# Altium schematic sheet Brainy Modul.SchDoc("Brainy Modul")
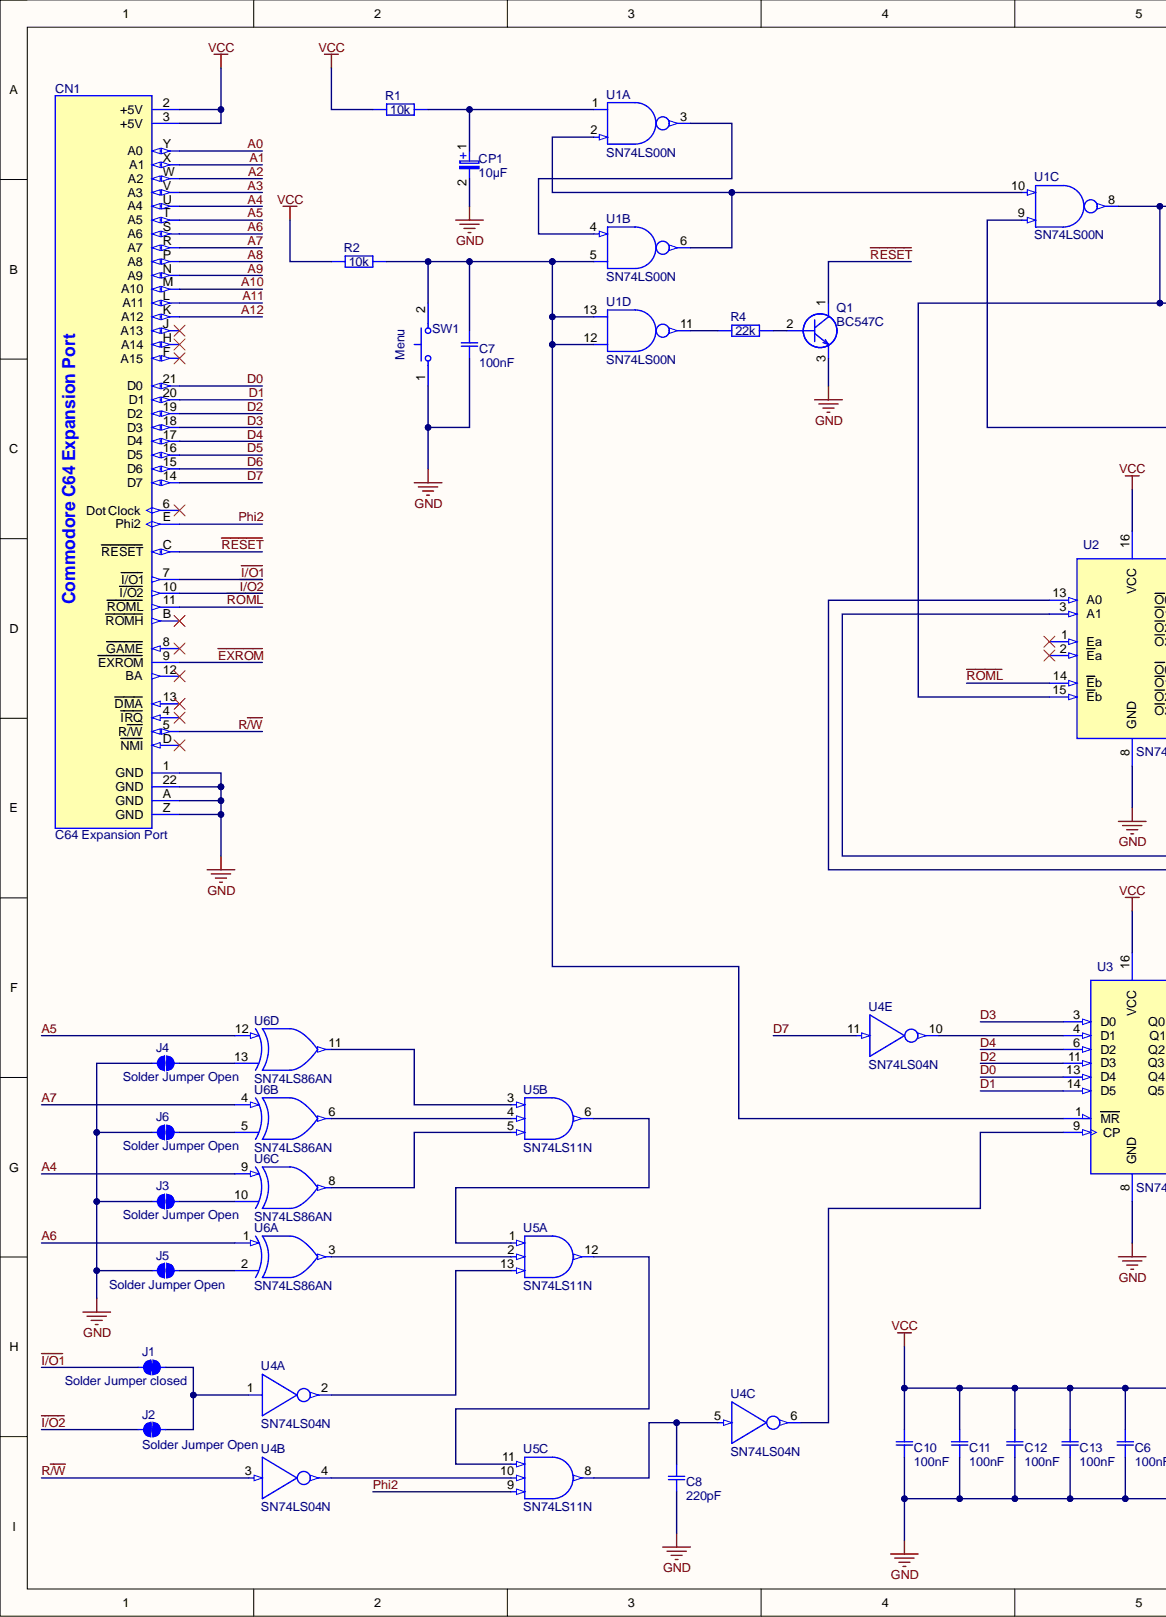
[diagram p0: Brainy Modul.SchDoc, left]
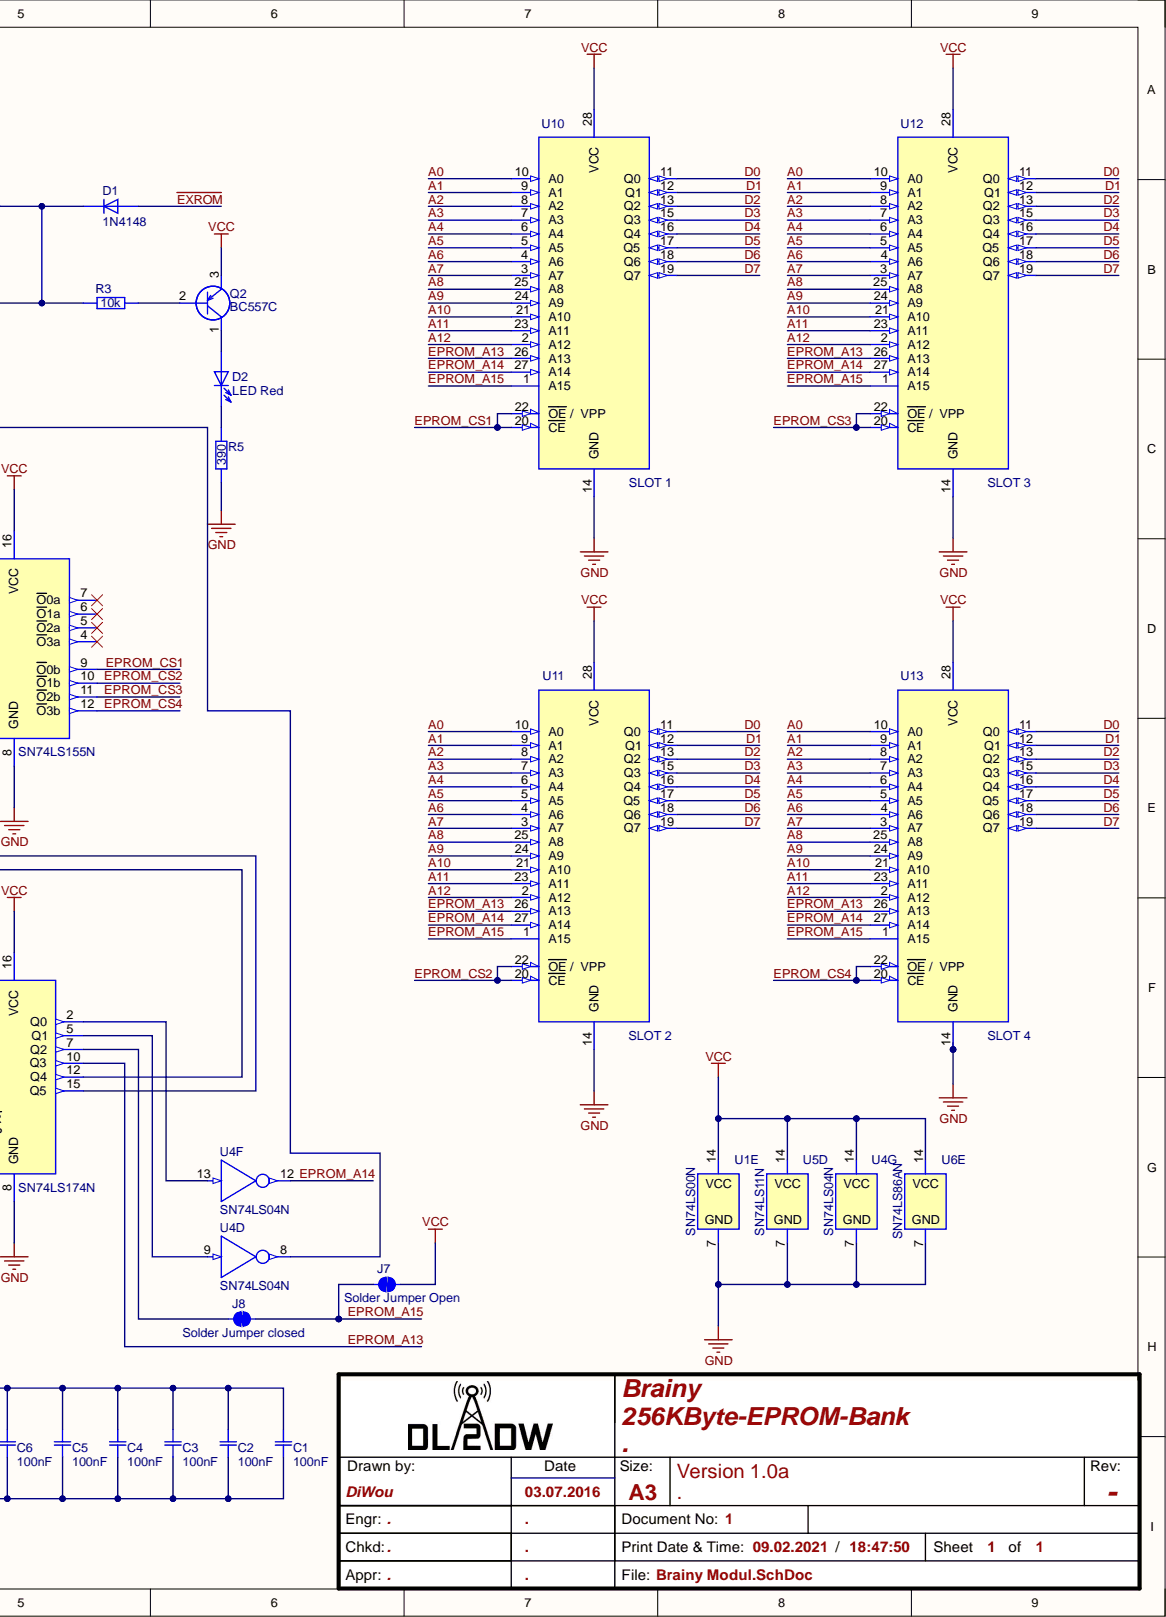
[diagram p1: Brainy Modul.SchDoc, right]

## Parts
C1  100nF  [CAP Disc 100nF P2.54mm D5.5mm T3mm]
C2  100nF  [CAP Disc 100nF P2.54mm D5.5mm T3mm]
C3  100nF  [CAP Disc 100nF P2.54mm D5.5mm T3mm]
C4  100nF  [CAP Disc 100nF P2.54mm D5.5mm T3mm]
C5  100nF  [CAP Disc 100nF P2.54mm D5.5mm T3mm]
C6  100nF  [CAP Disc 100nF P2.54mm D5.5mm T3mm]
C7  100nF  [CAP Disc 100nF P2.54mm D5.5mm T3mm]
C8  220pF  [KERKO 220P 63V RM2.5mm]
C10  100nF  [CAP Disc 100nF P2.54mm D5.5mm T3mm]
C11  100nF  [CAP Disc 100nF P2.54mm D5.5mm T3mm]
C12  100nF  [CAP Disc 100nF P2.54mm D5.5mm T3mm]
C13  100nF  [CAP Disc 100nF P2.54mm D5.5mm T3mm]
CN1  C64 Expansion Port  [C64 Expansion Port EDGE Connector]  (no BOM)
CP1  10µF  [CAP TAN 10µF T378E106K025AS P5.08mm]
D1  1N4148  [1N4148 P=5.08mm]
D2  LED Red  Color: Red  [LED 3mm Red Straight]
J1  Solder Jumper closed  [Solder Jumper Closed]
J2  Solder Jumper Open  [Solder Jumper Open Variant 2]  (no BOM)
J3  Solder Jumper Open  [Solder Jumper Open Variant 2]  (no BOM)
J4  Solder Jumper Open  [Solder Jumper Open Variant 2]  (no BOM)
J5  Solder Jumper Open  [Solder Jumper Open Variant 2]  (no BOM)
J6  Solder Jumper Open  [Solder Jumper Open Variant 2]  (no BOM)
J7  Solder Jumper Open  [Solder Jumper Open Variant 2]  (no BOM)
J8  Solder Jumper closed  [Solder Jumper Closed]
Q1  BC547C  [BC547C]
Q2  BC557C  [BC557C]
R1  10k  [10 kOhm R Axial DIN0207 L6.3mm D2.5mm P7.62mm Horizontal]
R2  10k  [10 kOhm R Axial DIN0207 L6.3mm D2.5mm P7.62mm Horizontal]
R3  10k  [10 kOhm R Axial DIN0207 L6.3mm D2.5mm P7.62mm Horizontal]
R4  22k  [22 kOhm R Axial DIN0207 L6.3mm D2.5mm P7.62mm Horizontal]
R5  390  [390 Ohm R Axial DIN0207 L6.3mm D2.5mm P7.62mm Horizontal]
SW1  Menu  [Tactile Switch Push Button Right Angle L=8.35mm]
U1  SN74LS00N  [SN74LS00N with Socket]  (parts A,B,C,D,E)
U2  SN74LS155N  [SN74LS155N with Socket]
U3  SN74LS174N  [SN74LS174N with Socket]
U4  SN74LS04N  [SN74LS04N with Socket]  (parts A,B,C,D,E,F,G)
U5  SN74LS11N  [SN74LS11N with Socket]  (parts A,B,C,D)
U6  SN74LS86AN  [SN74LS86N with Socket]  (parts A,B,C,D,E)
U10  SLOT 1  [Winbond W27C512-45Z DIP-28 with Socket]
U11  SLOT 2  [Winbond W27C512-45Z DIP-28 with Socket]
U12  SLOT 3  [Winbond W27C512-45Z DIP-28 with Socket]
U13  SLOT 4  [Winbond W27C512-45Z DIP-28 with Socket]

## Nets
GND: C1.1 C2.1 C3.1 C4.1 C5.1 C6.1 C7.1 C8.1 C10.1 C11.1 C12.1 C13.1 CN1.1(GND) CN1.22(GND) CN1.A(GND) CN1.Z(GND) CP1.2 J3.1 J4.1 J5.1 J6.1 Q1.3 R5.1 SW1.1 U1.7 U2.8(GND) U3.8(GND) U4.7 U5.7 U6.7 U10.14(GND) U11.14(GND) U12.14(GND) U13.14(GND)
VCC: C1.2 C2.2 C3.2 C4.2 C5.2 C6.2 C10.2 C11.2 C12.2 C13.2 CN1.2(+5V) CN1.3(+5V) J7.2 Q2.3 R1.1 R2.1 U1.14 U2.16(VCC) U3.16(VCC) U4.14 U5.14 U6.14 U10.28(VCC) U11.28(VCC) U12.28(VCC) U13.28(VCC)
~{EXROM}: CN1.9(EXROM) D1.2
~{I/O1}: CN1.7(I/O1) J1.1
~{I/O2}: CN1.10(I/O2) J2.1
~{RESET}: CN1.C(RESET) Q1.1
~{ROML}: CN1.11(ROML) U2.14(Eb)
A0: CN1.Y(A0) U10.10(A0) U11.10(A0) U12.10(A0) U13.10(A0)
A1: CN1.X(A1) U10.9(A1) U11.9(A1) U12.9(A1) U13.9(A1)
A2: CN1.W(A2) U10.8(A2) U11.8(A2) U12.8(A2) U13.8(A2)
A3: CN1.V(A3) U10.7(A3) U11.7(A3) U12.7(A3) U13.7(A3)
A4: CN1.U(A4) U6.9 U10.6(A4) U11.6(A4) U12.6(A4) U13.6(A4)
A5: CN1.T(A5) U6.12 U10.5(A5) U11.5(A5) U12.5(A5) U13.5(A5)
A6: CN1.S(A6) U6.1 U10.4(A6) U11.4(A6) U12.4(A6) U13.4(A6)
A7: CN1.R(A7) U6.4 U10.3(A7) U11.3(A7) U12.3(A7) U13.3(A7)
A8: CN1.P(A8) U10.25(A8) U11.25(A8) U12.25(A8) U13.25(A8)
A9: CN1.N(A9) U10.24(A9) U11.24(A9) U12.24(A9) U13.24(A9)
A10: CN1.M(A10) U10.21(A10) U11.21(A10) U12.21(A10) U13.21(A10)
A11: CN1.L(A11) U10.23(A11) U11.23(A11) U12.23(A11) U13.23(A11)
A12: CN1.K(A12) U10.2(A12) U11.2(A12) U12.2(A12) U13.2(A12)
D0: CN1.21(D0) U3.13(D4) U10.11(Q0) U11.11(Q0) U12.11(Q0) U13.11(Q0)
D1: CN1.20(D1) U3.14(D5) U10.12(Q1) U11.12(Q1) U12.12(Q1) U13.12(Q1)
D2: CN1.19(D2) U3.11(D3) U10.13(Q2) U11.13(Q2) U12.13(Q2) U13.13(Q2)
D3: CN1.18(D3) U3.3(D0) U10.15(Q3) U11.15(Q3) U12.15(Q3) U13.15(Q3)
D4: CN1.17(D4) U3.6(D2) U10.16(Q4) U11.16(Q4) U12.16(Q4) U13.16(Q4)
D5: CN1.16(D5) U10.17(Q5) U11.17(Q5) U12.17(Q5) U13.17(Q5)
D6: CN1.15(D6) U10.18(Q6) U11.18(Q6) U12.18(Q6) U13.18(Q6)
D7: CN1.14(D7) U4.11 U10.19(Q7) U11.19(Q7) U12.19(Q7) U13.19(Q7)
EPROM_A13: U3.10(Q3) U10.26(A13) U11.26(A13) U12.26(A13) U13.26(A13)
EPROM_A14: U4.12 U10.27(A14) U11.27(A14) U12.27(A14) U13.27(A14)
EPROM_A15: J7.1 J8.2 U10.1(A15) U11.1(A15) U12.1(A15) U13.1(A15)
EPROM_CS1: U2.9(O0b) U10.20(CE) U10.22(OE_/)
EPROM_CS2: U2.10(O1b) U11.20(CE) U11.22(OE_/)
EPROM_CS3: U2.11(O2b) U12.20(CE) U12.22(OE_/)
EPROM_CS4: U2.12(O3b) U13.20(CE) U13.22(OE_/)
Phi2: CN1.E(Phi2) U5.9
R~{/W}: CN1.5(R/W) U4.3
NetC7_2: C7.2 R2.2 SW1.2 U1.5 U1.12 U1.13 U3.1(MR)
NetC8_2: C8.2 U4.5 U5.8
NetCP1_1: CP1.1 R1.2 U1.1
NetD1_1: D1.1 R3.1 U1.8 U2.15(Eb)
NetD2_1: D2.1 R5.2
NetD2_2: D2.2 Q2.1
NetJ1_2: J1.2 J2.2 U4.1
NetJ3_2: J3.2 U6.10
NetJ4_2: J4.2 U6.13
NetJ5_2: J5.2 U6.2
NetJ6_2: J6.2 U6.5
NetJ8_1: J8.1 U3.7(Q2)
NetQ1_2: Q1.2 R4.2
NetQ2_2: Q2.2 R3.2
NetR4_1: R4.1 U1.11
NetU1_2: U1.2 U1.6 U1.10
NetU1_3: U1.3 U1.4
NetU1_9: U1.9 U4.8
NetU2_3: U2.3(A1) U3.15(Q5)
NetU2_13: U2.13(A0) U3.12(Q4)
NetU3_2: U3.2(Q0) U4.13
NetU3_4: U3.4(D1) U4.10
NetU3_5: U3.5(Q1) U4.9
NetU3_9: U3.9(CP) U4.6
NetU4_2: U4.2 U5.13
NetU4_4: U4.4 U5.10
NetU5_1: U5.1 U5.6
NetU5_2: U5.2 U6.3
NetU5_3: U5.3 U6.11
NetU5_4: U5.4 U6.6
NetU5_5: U5.5 U6.8
NetU5_11: U5.11 U5.12

Not connected: CN1 4(IRQ) 6(Dot_Clock) 8(GAME) 12(BA) 13(DMA) B(ROMH) D(NMI) F(A15) H(A14) J(A13); U2 1(Ea) 2(Ea) 4(O3a) 5(O2a) 6(O1a) 7(O0a)

## Library data of the parts
C1-C7 C10-C13 = CMP-Capacitors-Ceramic_Capacitors-00007-4: Ceramic Disc Capacitors 100nF -20%~+80% 50V Through Hole,P=2.54mm RoHS; Component Kind: Standard; ComponentLink1URL: https://lcsc.com/product-detail/Others_Dersonic-CC1H104ZA1PD3F5O3000_C353909.html; Library Name: PCB-Tech Cloud
C8 = CMP-Capacitors-Ceramic_Capacitors-00015-1: Keramischer Scheibenkondensator, bedrahtet. Für Printmontage, Rastermaß 2,5 mm.; Dielectric: C0G; Lead Diameter: 4mm; Lead/Base Style: Straight; Tolerance: 10%; Voltage Rating: 63V; Capacitance: 220pF; Case/Package: Radial; Component Kind: Standard; Height: 5; Height - Seated (Max): 5mm; Lead Pitch: 2.5mm; Length: 0.15inch; Library Name: PCB-Tech Cloud; Max Operating Temperature: 125°C; Min Operating Temperature: -55°C; Mount: Through Hole; Number of Pins: 2; Package Quantity: 3500; Packaging: Tape and Reel; REACH SVHC: No SVHC; Termination: Radial; Thickness: 2.2mm; Voltage Rating (DC): 63V; Width: 4mm
CN1 = CMP-Commodore-00008-4: Expansion Port EDGE Connector for Interface Cards; Library Name: PCB-Tech Cloud
CP1 = CMP-Capacitors-Tantalum_Capacitors-00001-5: Tantalum Capacitors - Solid Leaded 25volts 10uF 10%; Dissipation Factor: 6%; ESR (Equivalent Series Resistance): 2.5R; Leakage Current: 3uA; Polarity: Polar; Voltage Rating: 25V; Capacitance: 10uF; Case/Package: Molded; Component Kind: Standard; Depth: 3.3mm; Height: 3.3mm; Lead Pitch: 5.08mm; Length: 7.87mm; Library Name: PCB-Tech Cloud; Max Operating Temperature: 125°C; Min Operating Temperature: -55°C; Packaging: Bulk; Termination: Radial; Tolerance: 10%; Voltage Rating (DC): 25V; Width: 5.84mm
D1 = CMP-Diodes-00002-4: NEXPERIA - 1N4148 - DIODE, 1N4148 AMMO-BOX 10K; Capacitance: 4pF; Current: 4A; Current Rating: 200mA; Element Configuration: Single; Forward Voltage: 1V; Max Power Dissipation: 500mW; Max Repetitive Reverse Voltage (Vrrm): 100V; Polarity: Standard; Reverse Recovery Time: 4ns; Case/Package: SOD; Component Kind: Standard; Forward Current: 200mA; Height: 0.25inch; Lead Free: Lead Free; Length: 2.5inch; Library Name: PCB-Tech Cloud; Max Forward Surge Current (Ifsm): 4A; Max Operating Temperature: 200°C; Min Operating Temperature: -65°C; Number of Pins: 2; Output Current: 200mA; Packaging: Ammo Pack; Peak Non-Repetitive Surge Current: 4A; Radiation Hardening: No; REACH SVHC: No SVHC; Termination: Axial; Voltage: 100V; Voltage Rating (DC): 100V; Weight: 0.01lb; Width: 0.25inch
D2 = CMP-LEDs-00000-4: WURTH ELEKTRONIK   151033RS03000   LED, 3MM,  RED, 2600MCD, 621NM; Forward Current: 30mA; Forward Voltage: 2V; Lens Style: Clear,Circular,Round; Test Current: 20mA; Viewing Angle: 30°; Case/Package: Radial; Component Kind: Standard; Dominant Wavelength: 621nm; Height: 5.55mm; Lens Size: 3mm; Library Name: PCB-Tech Cloud; Luminous Intensity: 2600mcd; Max Operating Temperature: 85°C; Min Operating Temperature: -40°C; Mount: Through Hole; Package Quantity: 1; Packaging: Bulk; REACH SVHC: Unknown; Wavelength: 621nm
J1 J8 = CMP-Mechanicals-00017-7: Component Kind: Net Tie; Description: Solder Jumper Closed; Library Name: PCB-Tech Cloud
J2-J7 = CMP-Mechanicals-00016-14: Description: Solder Jumper Open; Library Name: PCB-Tech Cloud
Q1 = CMP-Transistors-00002-1: BC547C Diotec Bipolar NPN Transistor 45V; Collector Base Voltage (VCBO): 50V; Collector Emitter Saturation Voltage: 600mV; Emitter Base Voltage (VEBO): 5V; Frequency: 300MHz; Number of Channels: 1; Power Dissipation: 500mW; Case/Package: TO-92; Collector Emitter Voltage (VCEO): 45V; Component Kind: Standard; Library Name: PCB-Tech Cloud; Max Operating Temperature: 150°C; Min Operating Temperature: -55°C; Mount: Through Hole; Number of Elements: 1; Number of Pins: 3; Packaging: Tape and Reel; Polarity: NPN
Q2 = CMP-Transistors-00003-1: Trans GP BJT PNP 45V 0.1A Automotive 3-Pin TO-92 T/R; Collector Base Voltage (VCBO): 50V; Emitter Base Voltage (VEBO): 5V; Frequency: 150MHz; Number of Elements: 1; Power Dissipation: 500mW; Case/Package: TO-92; Collector Emitter Voltage (VCEO): 45V; Component Kind: Standard; Library Name: PCB-Tech Cloud; Max Operating Temperature: 150°C; Min Operating Temperature: -55°C; Mount: Through Hole; Number of Pins: 3; Packaging: Tape and Reel; Polarity: PNP
R1-R3 = CMP-Resistors-Yageo_THT_DIN_0207-00096-1: RES 10K OHM 1/4W 5% AXIAL; Max Power Dissipation: 250mW; Resistance: 10kR; Temperature Coefficient: 500ppm/°C; Case/Package: Axial; Component Kind: Standard; Composition: Carbon Film; Lead Free: Lead Free; Length: 0.248inch; Library Name: PCB-Tech Cloud; Max Operating Temperature: 155°C; Min Operating Temperature: -55°C; Number of Terminations: 2; Package Quantity: 200; Packaging: Bulk; Tolerance: 5%
R4 = CMP-Resistors-Yageo_THT_DIN_0207-00104-1: RES 22K OHM 1/4W 5% AXIAL; Max Power Dissipation: 250mW; Resistance: 22kR; Temperature Coefficient: 500ppm/°C; Case/Package: Axial; Component Kind: Standard; Composition: Carbon Film; Length: 0.248inch; Library Name: PCB-Tech Cloud; Max Operating Temperature: 155°C; Min Operating Temperature: -55°C; Number of Terminations: 2; Package Quantity: 200; Packaging: Bulk; Tolerance: 5%
R5 = CMP-Resistors-Yageo_THT_DIN_0207-00062-1: RES 390 OHM 1/4W 5% AXIAL; Max Power Dissipation: 250mW; Resistance: 390R; Temperature Coefficient: 500ppm/°C; Case/Package: Axial; Component Kind: Standard; Composition: Carbon Film; Lead Free: Lead Free; Length: 0.248inch; Library Name: PCB-Tech Cloud; Max Operating Temperature: 155°C; Min Operating Temperature: -55°C; Number of Terminations: 2; Package Quantity: 200; Packaging: Bulk; Power Rating: 250mW; Tolerance: 5%
SW1 = CMP-Switches-00004-1: SWITCH TACTILE SPST-NO 0.05A 24V; Actuator Length: 0.226inch; Actuator Material: PBT; Actuator Type: Standard; Contact Current Rating: 50mA; Contact Resistance: 100mR; Electrical Life: 100000Cycles; Illumination Color: Black; Illumination Type: Non-Illuminated; Operating Force: 160gf; Switch Function: Off-Mom; Switch Travel: 0.25mm; Throw Configuration: SPST; Component Kind: Standard; Contact Material: Copper Alloy; Contact Plating: Silver; Depth: 12.36mm; ELV: Compliant; Height: 7.3mm; Lead Free: Lead Free; Length: 7.11mm; Library Name: PCB-Tech Cloud; Max Current Rating: 50mA; Max Operating Temperature: 85°C; Max Voltage Rating (DC): 24V; Mechanical Life: 100000Cycles; Min Operating Temperature: -35°C; Mount: Through Hole; Orientation: Straight; Package Quantity: 1000; Packaging: Loose Piece; Radiation Hardening: No; Termination: PC Pins; Type: Switch; Width: 6mm
U1 = CMP-ICs-74xx-series-00000-3: IC, 74LS, 74LS00, DIP14, 5.25V; High Level Output Current: -400uA; Logic Function: NAND; Low Level Output Current: 8mA; Min Supply Voltage: 4.75V; Number of Bits: 4; Number of Input Lines: 2; Number of Output Lines: 1; Operating Supply Voltage: 5V; Output Current: 16mA; Propagation Delay: 15ns; Quiescent Current: 2.4mA; Schmitt Trigger Input: No; Case/Package: PDIP; Component Kind: Standard; Contact Plating: Gold; Height: 4.57mm; Lead Free: Lead Free; Length: 19.3mm; Library Name: PCB-Tech Cloud; Max Operating Temperature: 70°C; Max Supply Voltage: 5.25V; Min Operating Temperature: 0°C; Mount: Through Hole; Number of Circuits: 4; Number of Elements: 4; Number of Gates: 4; Number of Inputs: 2; Number of Outputs: 1; Number of Pins: 14; Package Quantity: 25; Packaging: Rail/Tube; Radiation Hardening: No; REACH SVHC: No SVHC; Turn-On Delay Time: 15ns; Weight: 0.032734oz; Width: 6.35mm
U2 = CMP-ICs-74xx-series-00058-1: Decoder/Demultiplexer Single/Dual 3/2-to-8/4 16-Pin PDIP; High Level Output Current: -100uA; Low Level Output Current: 8mA; Max Supply Voltage: 5.25V; Min Supply Voltage: 4.75V; Operating Supply Voltage: 5V; Polarity: Inverting; Propagation Delay: 51ns; Case/Package: PDIP; Component Kind: Standard; Library Name: PCB-Tech Cloud; Max Operating Temperature: 70°C; Min Operating Temperature: 0°C; Mount: Through Hole; Number of Pins: 16
U3 = CMP-ICs-74xx-series-00057-1: Flip Flop D-Type Bus Interface Pos-Edge 1-Element 16-Pin PDIP Tube; Clock Edge Trigger Type: Positive Edge; Frequency: 40MHz; High Level Output Current: -400uA; Logic Function: D-Type,Flip-Flop; Low Level Output Current: 8mA; Max Supply Voltage: 5.25V; Min Supply Voltage: 4.75V; Number of Bits: 6; Number of Circuits: 7; Number of Elements: 1; Number of Input Lines: 2; Operating Supply Voltage: 5V; Output Type: TTL; Polarity: Non-Inverting; Propagation Delay: 30ns; Turn-On Delay Time: 20ns; Case/Package: PDIP; Component Kind: Standard; Height: 4.57mm; Lead Free: Lead Free; Length: 19.3mm; Library Name: PCB-Tech Cloud; Max Frequency: 40MHz; Max Operating Temperature: 70°C; Min Operating Temperature: 0°C; Mount: Through Hole; Number of Bits per Element: 6; Number of Output Lines: 2; Number of Pins: 16; Output Current: 8mA; Package Quantity: 25; Packaging: Rail/Tube; Radiation Hardening: No; REACH SVHC: No SVHC; Weight: 0.03357oz; Width: 6.35mm
U4 = CMP-ICs-74xx-series-00006-2: IC HEX INVERTER 14-DIP; High Level Output Current: -400uA; Logic Function: Buffer,Inverter; Low Level Output Current: 8mA; Max Supply Voltage: 5.25V; Min Supply Voltage: 4.75V; Nominal Supply Current: 100uA; Number of Bits: 6; Number of Inputs: 1; Operating Supply Voltage: 5V; Propagation Delay: 15ns; Schmitt Trigger Input: No; Turn-On Delay Time: 22ns; Case/Package: PDIP; Component Kind: Standard; Contact Plating: Gold; Height: 4.57mm; Lead Free: Lead Free; Length: 19.3mm; Library Name: PCB-Tech Cloud; Max Operating Temperature: 70°C; Max Output Current: 8mA; Min Operating Temperature: 0°C; Mount: Through Hole; Number of Circuits: 6; Number of Elements: 6; Number of Gates: 6; Number of Output Lines: 6; Number of Pins: 14; Output Current: 8mA; Package Quantity: 25; Packaging: Rail/Tube; Radiation Hardening: No; REACH SVHC: No SVHC; Termination: Through Hole; Weight: 0.032734oz; Width: 6.35mm
U5 = CMP-ICs-74xx-series-00011-2: IC GATE AND 3CH 3-INP 14DIP; High Level Output Current: -400uA; Low Level Output Current: 8mA; Max Supply Voltage: 5.25V; Min Supply Voltage: 4.75V; Number of Bits: 3; Number of Output Lines: 1; Operating Supply Voltage: 5V; Propagation Delay: 20ns; Quiescent Current: 3.3mA; Schmitt Trigger Input: No; Case/Package: PDIP; Component Kind: Standard; Height: 4.57mm; Lead Free: Lead Free; Length: 19.3mm; Library Name: PCB-Tech Cloud; Logic Function: AND; Max Operating Temperature: 70°C; Max Output Current: 8mA; Min Operating Temperature: 0°C; Mount: Through Hole; Number of Circuits: 3; Number of Elements: 3; Number of Gates: 3; Number of Input Lines: 3; Number of Inputs: 3; Number of Outputs: 1; Number of Pins: 14; Output Current: 8mA; Package Quantity: 25; Packaging: Rail/Tube; Radiation Hardening: No; REACH SVHC: No SVHC; Termination: Through Hole; Turn-On Delay Time: 20ns; Weight: 0.032734oz; Width: 6.35mm
U6 = CMP-ICs-74xx-series-00039-2: IC GATE XOR 4CH 2-INP 14-DIP; High Level Output Current: -400uA; Logic Function: XOR,OR; Low Level Output Current: 8mA; Max Supply Voltage: 5.25V; Min Supply Voltage: 4.75V; Number of Bits: 4; Number of Input Lines: 2; Number of Output Lines: 1; Operating Supply Voltage: 5V; Propagation Delay: 30ns; Quiescent Current: 6.1mA; Schmitt Trigger Input: No; Case/Package: PDIP; Component Kind: Standard; Contact Plating: Gold; Height: 4.57mm; Lead Free: Lead Free; Length: 19.3mm; Library Name: PCB-Tech Cloud; Max Operating Temperature: 70°C; Min Operating Temperature: 0°C; Mount: Through Hole; Number of Circuits: 4; Number of Elements: 4; Number of Gates: 4; Number of Inputs: 2; Number of Outputs: 1; Number of Pins: 14; Output Current: 8mA; Package Quantity: 25; Packaging: Rail/Tube; Radiation Hardening: No; REACH SVHC: No SVHC; Turn-On Delay Time: 30ns; Weight: 0.032734oz; Width: 6.35mm
U10-U13 = CMP-ICs-Memory-00001-2: IC EEPROM 512KBIT 45NS 28DIP; Density: 512Kb; Frequency: 45GHz; Interface: Parallel; Memory Size: 512000B; Operating Supply Voltage: 5V; Access Time: 45ns; Case/Package: DIP; Component Kind: Standard; Lead Free: Lead Free; Library Name: PCB-Tech Cloud; Max Operating Temperature: 70°C; Memory Type: EEPROM; Min Operating Temperature: 0°C; Number of Pins: 28; Package Quantity: 15; Packaging: Rail/Tube; Radiation Hardening: No
